# Altium schematic sheet Power Board-MCU.SchDoc
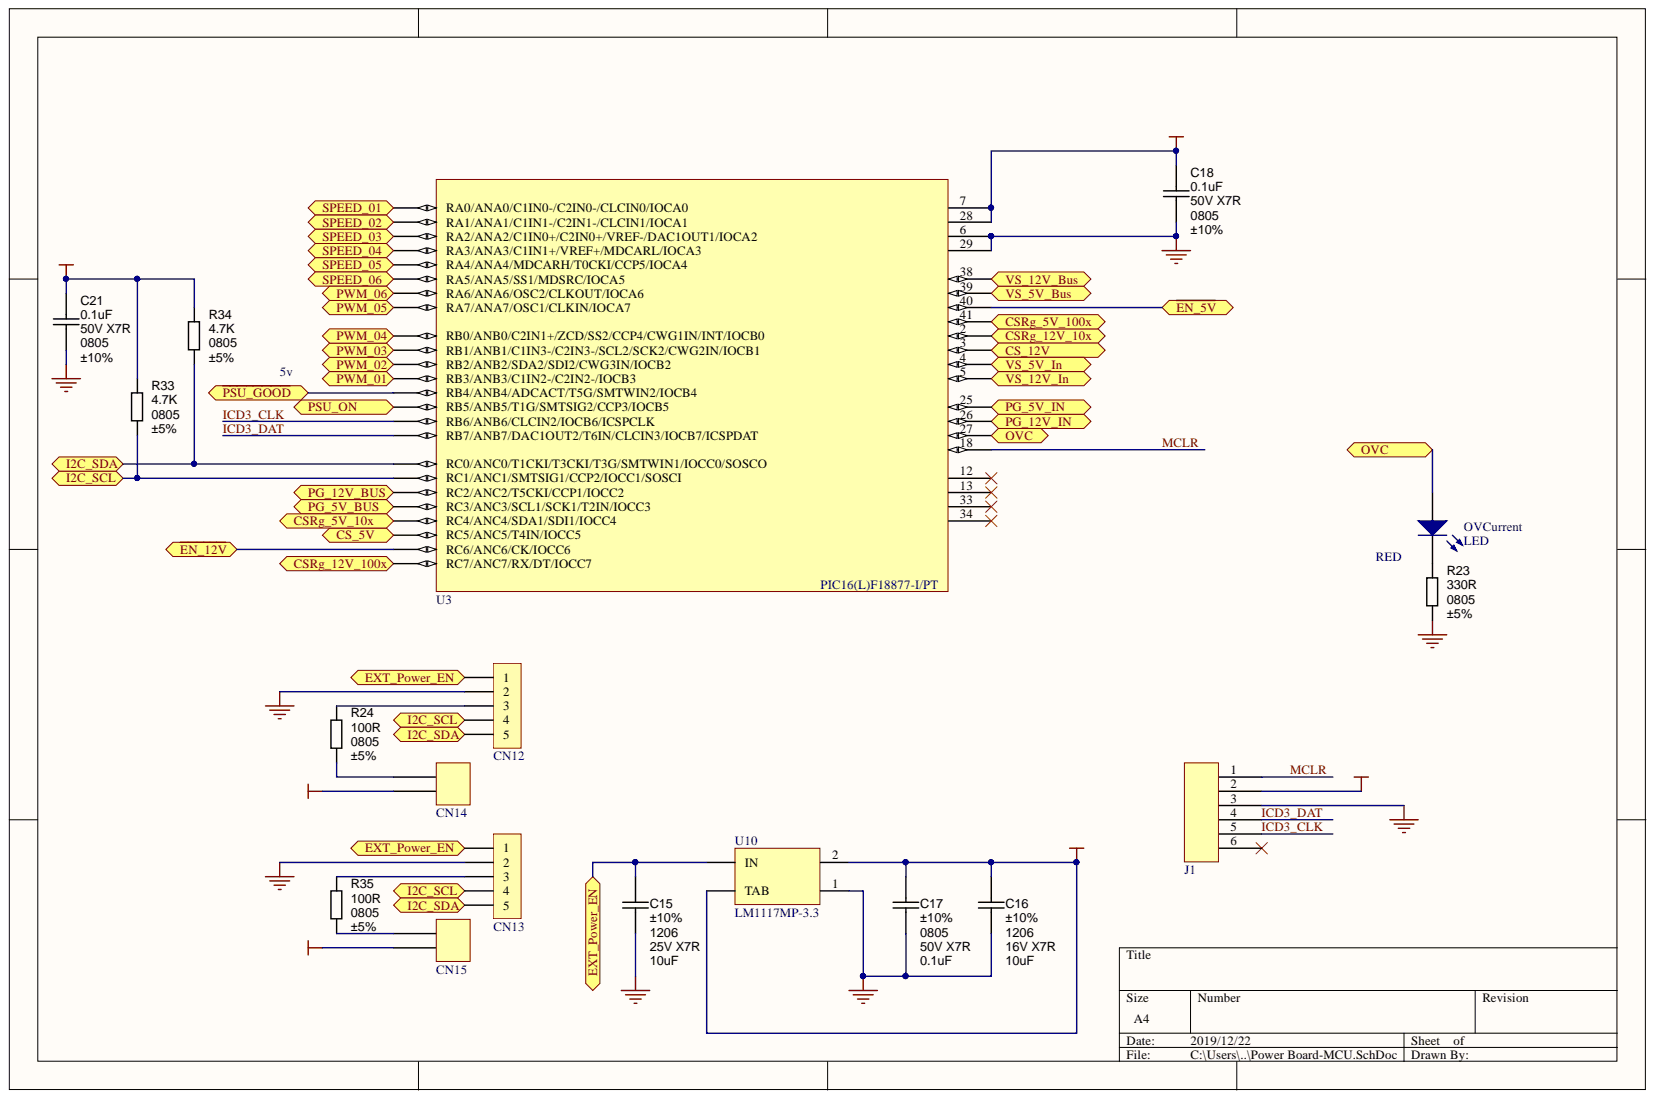
[diagram p0: Power Board-MCU.SchDoc]

## Parts
C15  value 10uF  Package / Case: 1206  Tolerance: ±10%  [C1206-EIA-LevelB]  (hidden comment: CAPC_10uF_25V_X7R_1206_10%)
C16  value 10uF  Package / Case: 1206  Ratings: 16V X7R  Tolerance: ±10%  [C1206-EIA-LevelB]  (hidden comment: CAPC_10uF_16V_X7R_1206_10%)
C17  value 0.1uF  Package / Case: 0805  Tolerance: ±10%  [C0805-EIA-LevelB]  (hidden comment: CAPC_0.1uF_50V_X7R_0805_10%)
C18  value 0.1uF  Package / Case: 0805  Tolerance: ±10%  [C0805-EIA-LevelB]  (hidden comment: CAPC_0.1uF_50V_X7R_0805_10%)
C21  value 0.1uF  Package / Case: 0805  Tolerance: ±10%  [C0805-EIA-LevelB]  (hidden comment: CAPC_0.1uF_50V_X7R_0805_10%)
CN12  XH 2.5mm 05 PIN 1Row  [05 PIN XH 2.5mm 1Row]  (value not drawn)
CN13  XH 2.5mm 05 PIN 1Row  [05 PIN XH 2.5mm 1Row]  (value not drawn)
CN14  02 PIN HDR 2.54mm 1Row 961 Series  [02 PIN HDR 2.54mm 1Row 961 Series]  (value not drawn)
CN15  02 PIN HDR 2.54mm 1Row 961 Series  [02 PIN HDR 2.54mm 1Row 961 Series]  (value not drawn)
J1  06 PIN HDR 2.54mm 1Row 961 Series  [06 PIN HDR 2.54mm 1Row 961 Series]  (value not drawn)
OVCurrent  LED  [LED-0805]
R23  value 330R  Package / Case: 0805  Tolerance: ±5%  [RCS0805-e3]  (hidden comment: RES_330R_0805_5%)
R24  value 100R  Package / Case: 0805  Tolerance: ±5%  [RCS0805-e3]  (hidden comment: Thick Film)
R33  value 4.7K  Package / Case: 0805  Tolerance: ±5%  [RCS0805-e3]  (hidden comment: RES_4.7K_0805_5%)
R34  value 4.7K  Package / Case: 0805  Tolerance: ±5%  [RCS0805-e3]  (hidden comment: RES_4.7K_0805_5%)
R35  value 100R  Package / Case: 0805  Tolerance: ±5%  [RCS0805-e3]  (hidden comment: Thick Film)
U3  PIC16(L)F18877-I/PT  [TQFP80P1200X1200X120-44N]
U10  LM1117MP-3.3  [MP04A_N]

## Ports
CS_5V, CS_12V, CSRg_5V_10x, CSRg_5V_100x, CSRg_12V_10x, CSRg_12V_100x, EXT_Power_EN, I2C_SCL, I2C_SDA, OVC, PG_5V_BUS, PG_5V_IN, PG_12V_BUS, PG_12V_IN, PSU_ON, PWM_01, PWM_02, PWM_03, PWM_04, PWM_05, PWM_06, SPEED_01, SPEED_02, SPEED_03, SPEED_04, SPEED_05, SPEED_06, VS_5V_Bus, VS_5V_In, VS_12V_Bus, VS_12V_In, ~{EN_5V}, ~{EN_12V}, ~{PSU_GOOD}

## Nets
GND: C15.2 C16.2 C17.2 C18.2 C21.2 CN12.2 CN13.2 J1.3 R23.2 U3.6 U3.29 U10.1
P3V3: C16.1 C17.1 C18.1 C21.1 CN14.2 CN15.2 J1.2 R33.1 R34.1 U3.7 U3.28 U10.2 U10.4(TAB)
~{EN_5V}: U3.40 ; port ~{EN_5V}
~{PSU_GOOD}: U3.14(RB4/ANB4/ADCACT/T5G/SMTWIN2/IOCB4) ; port ~{PSU_GOOD}
CS_5V: U3.43(RC5/ANC5/T4IN/IOCC5) ; port CS_5V
CS_12V: U3.3 ; port CS_12V
CSRg_5V_10x: U3.42(RC4/ANC4/SDA1/SDI1/IOCC4) ; port CSRg_5V_10x
CSRg_5V_100x: U3.41 ; port CSRg_5V_100x
CSRg_12V_10x: U3.2 ; port CSRg_12V_10x
CSRg_12V_100x: U3.1(RC7/ANC7/RX/DT/IOCC7) ; port CSRg_12V_100x
~{EN_12V}: U3.44(RC6/ANC6/CK/IOCC6) ; port ~{EN_12V}
EXT_Power_EN: C15.1 CN12.1 CN13.1 U10.3(IN) ; port EXT_Power_EN
I2C_SCL: CN12.4 CN13.4 R33.2 U3.35(RC1/ANC1/SMTSIG1/CCP2/IOCC1/SOSCI) ; port I2C_SCL
I2C_SDA: CN12.5 CN13.5 R34.2 U3.32(RC0/ANC0/T1CKI/T3CKI/T3G/SMTWIN1/IOCC0/SOSCO) ; port I2C_SDA
ICD3_CLK: J1.5 U3.16(RB6/ANB6/CLCIN2/IOCB6/ICSPCLK)
ICD3_DAT: J1.4 U3.17(RB7/ANB7/DAC1OUT2/T6IN/CLCIN3/IOCB7/ICSPDAT)
MCLR: J1.1 U3.18
OVC: OVCurrent.1 U3.27 ; port OVC
PG_5V_BUS: U3.37(RC3/ANC3/SCL1/SCK1/T2IN/IOCC3) ; port PG_5V_BUS
PG_5V_IN: U3.25 ; port PG_5V_IN
PG_12V_BUS: U3.36(RC2/ANC2/T5CKI/CCP1/IOCC2) ; port PG_12V_BUS
PG_12V_IN: U3.26 ; port PG_12V_IN
PSU_ON: U3.15(RB5/ANB5/T1G/SMTSIG2/CCP3/IOCB5) ; port PSU_ON
PWM_01: U3.11(RB3/ANB3/C1IN2-/C2IN2-/IOCB3) ; port PWM_01
PWM_02: U3.10(RB2/ANB2/SDA2/SDI2/CWG3IN/IOCB2) ; port PWM_02
PWM_03: U3.9(RB1/ANB1/C1IN3-/C2IN3-/SCL2/SCK2/CWG2IN/IOCB1) ; port PWM_03
PWM_04: U3.8(RB0/ANB0/C2IN1+/ZCD/SS2/CCP4/CWG1IN/INT/IOCB0) ; port PWM_04
PWM_05: U3.30(RA7/ANA7/OSC1/CLKIN/IOCA7) ; port PWM_05
PWM_06: U3.31(RA6/ANA6/OSC2/CLKOUT/IOCA6) ; port PWM_06
SPEED_01: U3.19(RA0/ANA0/C1IN0-/C2IN0-/CLCIN0/IOCA0) ; port SPEED_01
SPEED_02: U3.20(RA1/ANA1/C1IN1-/C2IN1-/CLCIN1/IOCA1) ; port SPEED_02
SPEED_03: U3.21(RA2/ANA2/C1IN0+/C2IN0+/VREF-/DAC1OUT1/IOCA2) ; port SPEED_03
SPEED_04: U3.22(RA3/ANA3/C1IN1+/VREF+/MDCARL/IOCA3) ; port SPEED_04
SPEED_05: U3.23(RA4/ANA4/MDCARH/T0CKI/CCP5/IOCA4) ; port SPEED_05
SPEED_06: U3.24(RA5/ANA5/SS1/MDSRC/IOCA5) ; port SPEED_06
VS_5V_Bus: U3.39 ; port VS_5V_Bus
VS_5V_In: U3.4 ; port VS_5V_In
VS_12V_Bus: U3.38 ; port VS_12V_Bus
VS_12V_In: U3.5 ; port VS_12V_In
NetCN12_3: CN12.3 R24.2
NetCN13_3: CN13.3 R35.2
NetCN14_1: CN14.1 R24.1
NetCN15_1: CN15.1 R35.1
NetOVCurrent_2: OVCurrent.2 R23.1
N00030:

Not connected: J1 6; U3 12 13 33 34

## Library data of the parts
C15 = CAPC_10uF_25V_X7R_1206_10%: Capacitor SMD Cer 10uF 25V X7R 1206 10%; Manufacturer 1: Murata Electronics; Manufacturer Part Number 1: C1206X106K3RACTU; Ratings: 25V X7R; SCDType: undef; Component Kind: Standard; Operating Temperature: -55°C ~ 125°C; Library Name: roger225_Altium_Library.IntLib
C16 = CAPC_10uF_16V_X7R_1206_10%: Capacitor SMD Cer 10uF 16V X7R 1206 10%; Manufacturer 1: Murata Electronics; Manufacturer Part Number 1: C3216X7R1C106K160AC; SCDType: undef; Component Kind: Standard; Operating Temperature: -55°C ~ 125°C; Library Name: roger225_Altium_Library.IntLib
C17 C18 C21 = CAPC_0.1uF_50V_X7R_0805_10%: Capacitor SMD Cer 0.1uF 50V X7R 0805 10% 100nF; Manufacturer 1: Murata Electronics; Manufacturer Part Number 1: GCD21BR71H104KA01L; Ratings: 50V X7R; SCDType: undef; Component Kind: Standard; Operating Temperature: -55°C ~ 125°C; Library Name: roger225_Altium_Library.IntLib
CN12 CN13 = XH 2.5mm 05 PIN 1Row: CONN HEADER XH TOP 2.5MM; Component Kind: Standard; Library Name: roger225_Altium_Library.IntLib
OVCurrent = LED: Typical INFRARED GaAs LED; Component Kind: Standard; LatestRevisionDate: 08-Jul-2005; LatestRevisionNote: Mech Layer 1 removed.; PackageDescription: LED; 2 Leads; PackageReference: LED-0; Published: 8-Jun-2000; Simulation: LED0; Library Name: roger225_Altium_Library.IntLib; Publisher: Altium Limited
R23 = RES_330R_0805_5%: Resistor SMD chip 330 Ohm 0.125W 5% 0805; Ratings: 1/8W; SCDType: spec; Component Kind: Standard; Supplier 1: Digi-Key; Supplier Part Number 1: 541-1.00KCCT-ND; Library Name: roger225_Altium_Library.IntLib
R24 R35 = RES_100R_0805_5%: Resistor SMD chip 100 Ohm 0.125W 5% 0805; Ratings: 1/8W; SCDType: spec; Component Kind: Standard; Supplier 1: Digi-Key; Supplier Part Number 1: 541-1.00KCCT-ND; Library Name: roger225_Altium_Library.IntLib
R33 R34 = RES_4.7K_0805_5%: Resistor SMD chip 4.7k Ohm 0.125W 5% 0805; Manufacturer 1: Vishay/Dale; Manufacturer Part Number 1: CRCW08054K70JNEA; Ratings: 1/8W; SCDType: spec; Component Kind: Standard; Library Name: roger225_Altium_Library.IntLib
U10 = LM1117MP-3.3: 800mA Low-Dropout Linear Regulator; Manufacturer: National Semiconductor; CompType: LDO IC; PackageTopMarking: XYTT*N05A; PowerWise: N; Code_IPC: SOT223-4; Code_JEDEC: TO-261-AA; Component Kind: Standard; ComponentLink1Description: LM1117 Datasheet; ComponentLink1URL: http://www.national.com/ds/LM/LM1117.pdf; ComponentLink2Description: LM1117 Web Page; ComponentLink2URL: http://www.national.com/pf/LM/LM1117.html; ComponentLink3Description: MP04A Package Page; ComponentLink3URL: http://www.national.com/packaging/folders/mp04a.html; DatasheetVersion: Apr-2006; DigiKeyPartNumber: LM1117MP-3.3CT-ND; Library Name: NSC LDO.IntLib; PackageDescription: Molded SOT-223, 6.50 x 3.56 x 1.6mm Body, 4 Lead, 2.3mm Pitch; PackageReference: MP04A; PackageVersion: revB, Apr-2003; PartNumber: LM1117MP-3.3; PinCount: 4; Published: 4-Dec-2008; RoHS: O; Publisher: Altium Limited
CN14 CN15 = 02 PIN HDR 2.54mm 1Row 961 Series: Component Kind: Standard; Library Name: roger225_Altium_Library.IntLib
J1 = 06 PIN HDR 2.54mm 1Row 961 Series: Component Kind: Standard; Library Name: roger225_Altium_Library.IntLib
U3 = PIC16(L)F18877-I/PT: Component Kind: Standard; ComponentLink1Description: Manufacturer Link; ComponentLink1URL: http://www.microchip.com; ComponentLink2Description: Datasheet; ComponentLink2URL: http://ww1.microchip.com/downloads/en/DeviceDoc/PIC16(L)F18857_77%20Data%20Sheet_%20DS40001825C.pdf; Description: PIC16(L)F18877-I/PT; Library Name: Microchip.IntLib; PackageDescription: 44-Lead Plastic Thin Quad Flatpack (PT) - 10x10x1mm Body, 2.00mm [TQFP]; PackageReference: TQFP-PT44
